# Altium schematic sheet POWER.SchDoc
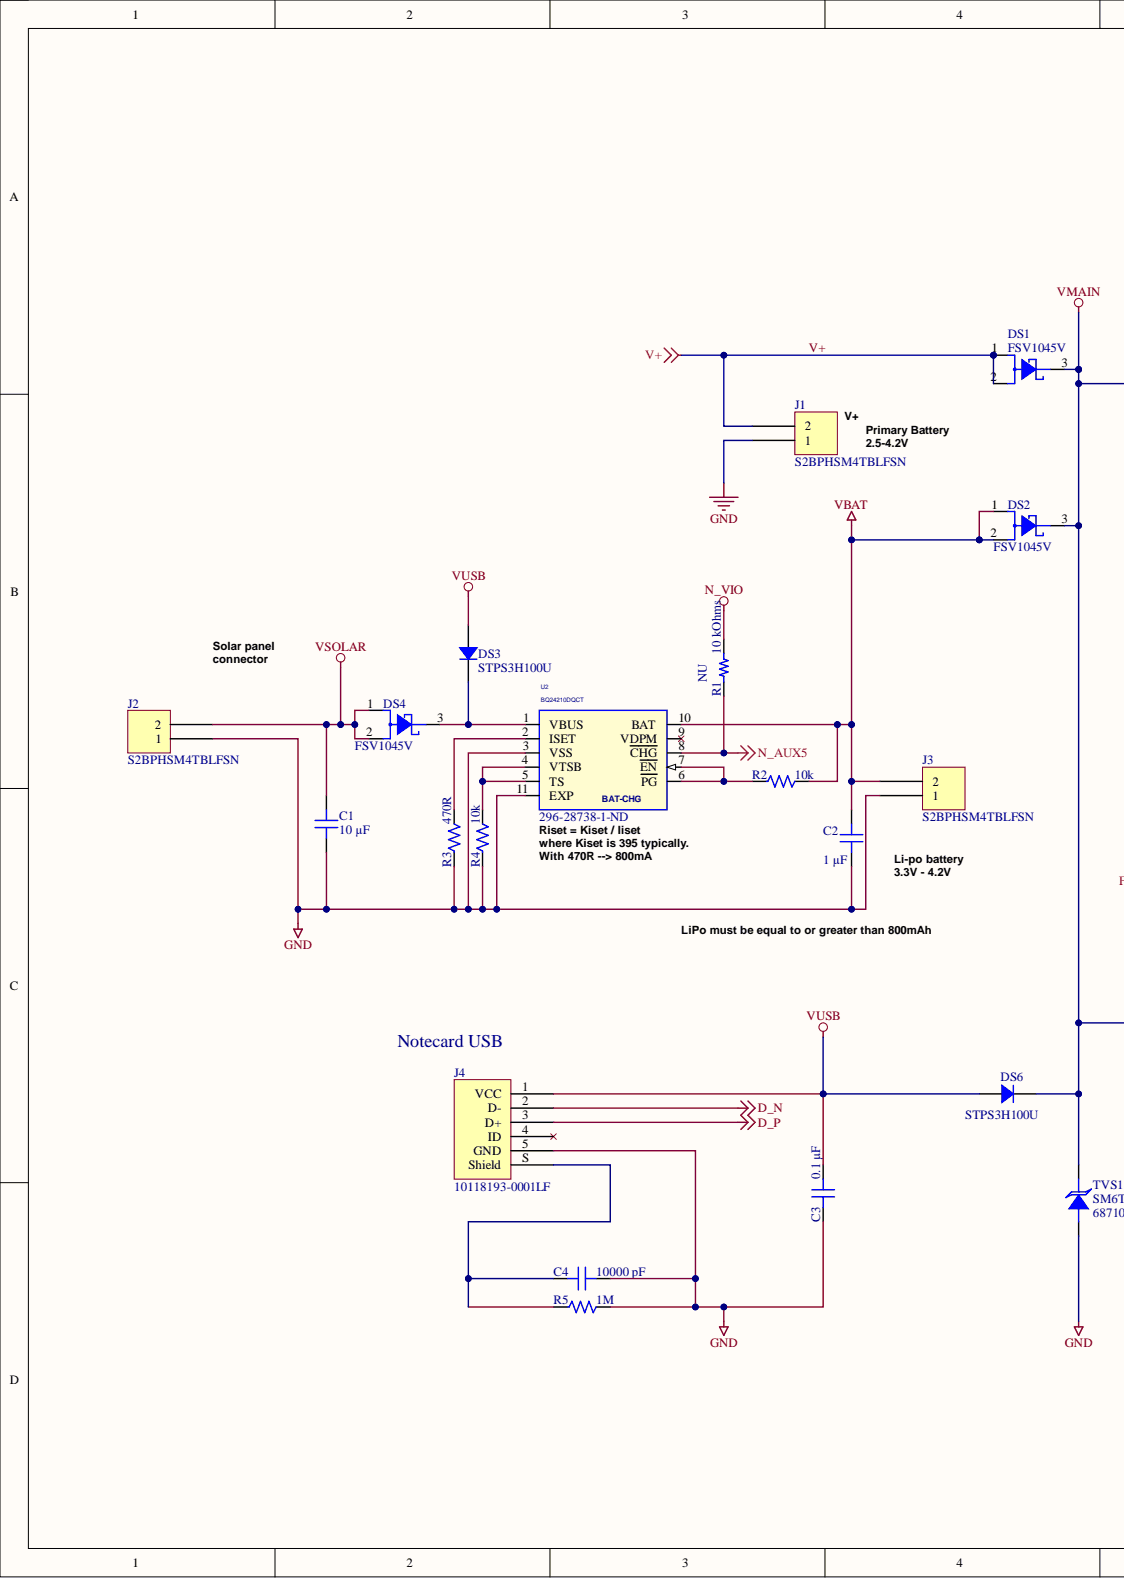
[diagram p0: POWER.SchDoc, left]
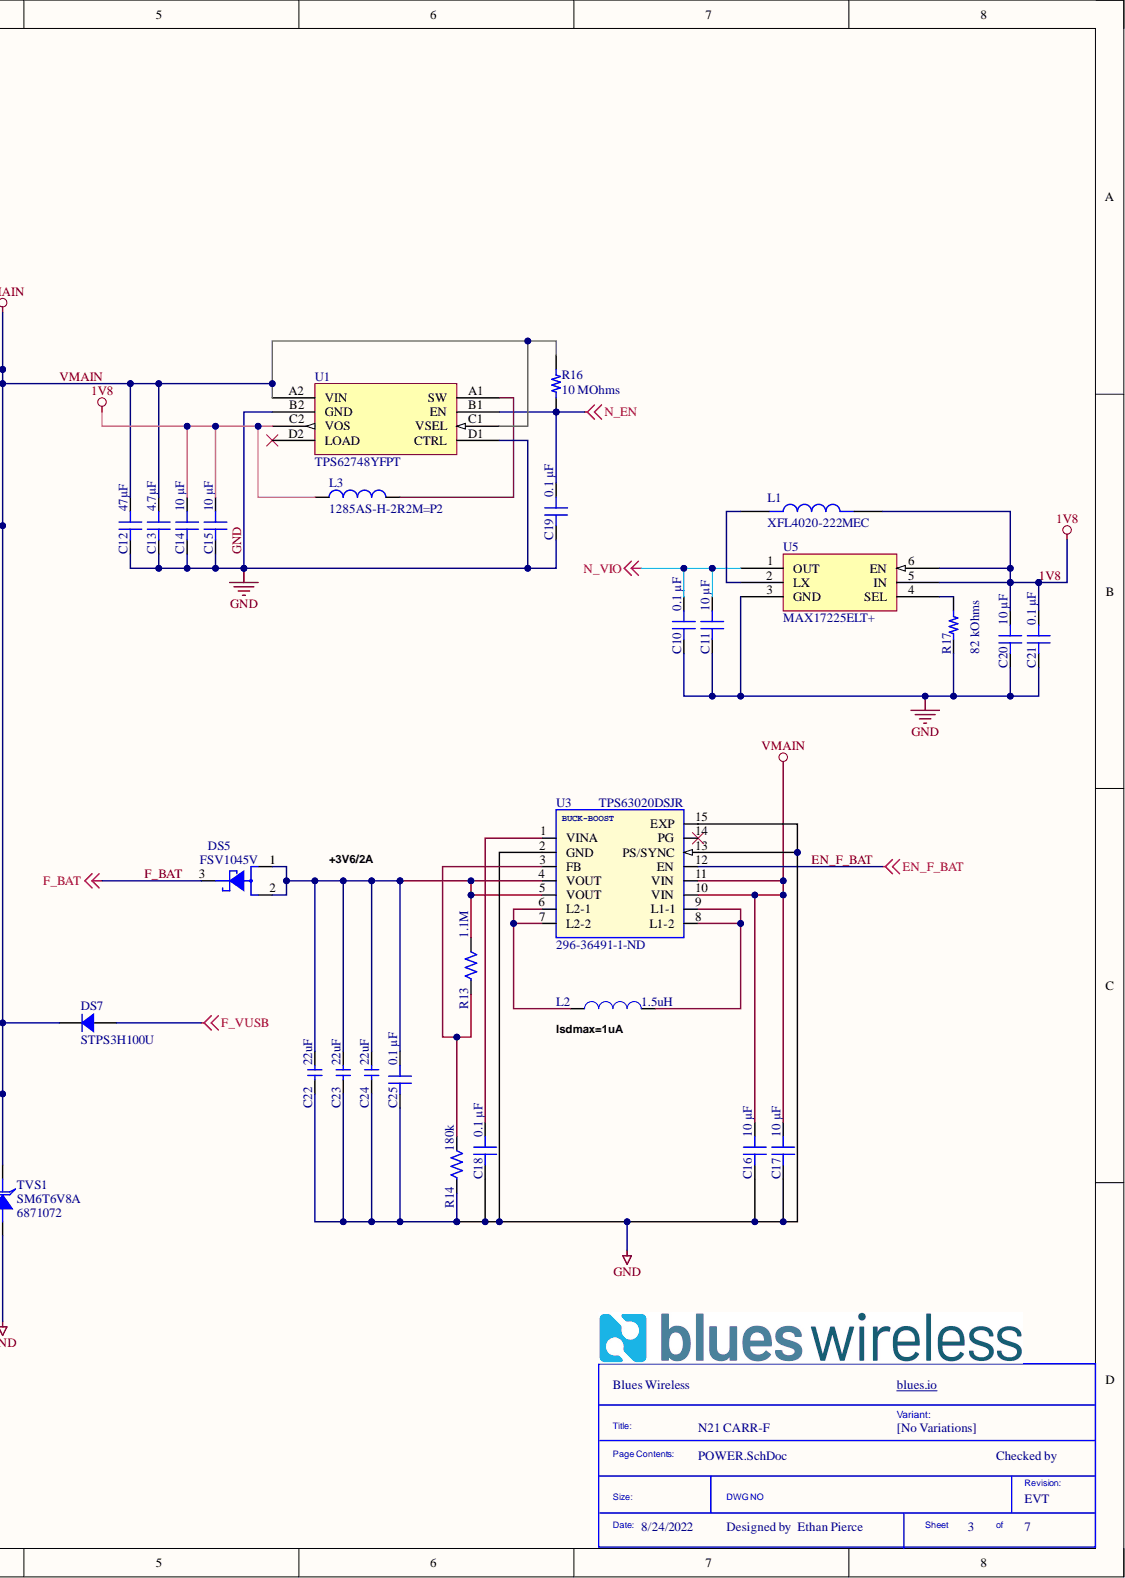
[diagram p1: POWER.SchDoc, right]

## Parts
C1  10 µF  [CAP 1206_3216 - 0.8MM]
C2  1 µF  [CAP 0402_1005]
C3  0.1 µF  [CAP 0402_1005]
C4  10000 pF  [CAP 1206_3216 - 0.8MM]
C10  0.1 µF  [CAP 0402_1005]
C11  10 µF  [CAP 0402_1005]
C12  47µF  [CAP 1210_3225 - 2.8MM]
C13  4.7µF  [CAP 0603_1608]
C14  10 µF  [CAP 0402_1005]
C15  10 µF  [CAP 0402_1005]
C16  10 µF  [CAP 0603_1608]
C17  10 µF  [CAP 0603_1608]
C18  0.1 µF  [CAP 0402_1005]
C19  0.1 µF  [CAP 0402_1005]
C20  10 µF  [CAP 0402_1005]
C21  0.1 µF  [CAP 0402_1005]
C22  22uF  [CAP FILM 0805]
C23  22uF  [CAP FILM 0805]
C24  22uF  [CAP FILM 0805]
C25  0.1 µF  [CAP 0402_1005]
DS1  FSV1045V  [TO277-3]
DS2  FSV1045V  [TO277-3]
DS3  STPS3H100U  [DO214AA SMB]
DS4  FSV1045V  [TO277-3]
DS5  FSV1045V  [TO277-3]
DS6  STPS3H100U  [DO214AA SMB]
DS7  STPS3H100U  [DO214AA SMB]
J1  S2BPHSM4TBLFSN  [JST S2B-PH-SM4-TB]
J2  S2BPHSM4TBLFSN  [JST S2B-PH-SM4-TB]
J3  S2BPHSM4TBLFSN  [JST S2B-PH-SM4-TB]
J4  10118193-0001LF  [FCI USB 10118193-0001LF]
L1  XFL4020-222MEC  [IND_XFL4020-222MEC]
L2  1.5uH  [VCHA042A-1R5MS6]
L3  1285AS-H-2R2M=P2  [1285AS-H-2R2M=P2-INDC2016X100N]
R1  10 kOhms  [RES 0402_1005]  (no BOM)
R2  10k  [RES 0402_1005]
R3  470R  [RES 0402_1005]
R4  10k  [RES 0402_1005]
R5  1M  [RES 1206_3216]
R13  1.1M  [RES 0402_1005]
R14  180k  [RES 0402_1005]
R16  10 MOhms  [RES 0402_1005]
R17  82 kOhms  [RES 0402_1005]
TVS1  SM6T6V8A  Supplier Part Number 1: 6871072  [SMB]
U1  TPS62748YFPT  [TPS62748YFPT-DSBGA4x2]
U2  BQ24210DQCT  Supplier Part Number 1: 296-28738-1-ND  [WSON10-EXP]
U3  TPS63020DSJR  ROOM: BUCK-BOOST  Supplier Part Number 1: 296-36491-1-ND  [TI PVSON-14 DSJ]
U5  MAX17225ELT+  [MAX17225ELT+UDFN]

## Nets
D_N: J4.2(D-)
D_P: J4.3(D+)
F_VUSB: DS7.1
N_AUX5: R1.1 U2.8(CHG)
N_EN: C19.1 R16.1 U1.B1(EN)
N_VIO: C10.1 C11.2 R1.2 U5.1(OUT)
VBAT: C2.2 DS2.1 DS2.2 J3.2 R2.2 U2.10(BAT)
VSOLAR: C1.1 DS4.1 DS4.2 J2.2
VUSB: C3.1 DS3.1 DS6.1 J4.1(VCC)
1V8: C14.2 C15.2 C20.2 C21.1 L1.2 L3.1 U1.C2(VOS) U5.5(IN) U5.6(EN)
EN_F_BAT: U3.12(EN)
F_BAT: DS5.3
GND: C1.2 C2.1 C3.2 C4.2 C10.2 C11.1 C12.1 C13.1 C14.1 C15.1 C16.1 C17.1 C18.1 C19.2 C20.1 C21.2 C22.2 C23.2 C24.2 C25.1 J1.1 J2.1 J3.1 J4.5(GND) R3.1 R4.1 R5.2 R14.1 R17.1 TVS1.2 U1.B2(GND) U1.D1(CTRL) U2.3(VSS) U2.11(EXP) U3.2(GND) U3.13(PS/SYNC) U3.15(EXP) U5.3(GND)
V+: DS1.1 DS1.2 J1.2
VMAIN: C12.2 C13.2 C16.2 C17.2 DS1.3 DS2.3 DS6.2 DS7.2 R16.2 TVS1.1 U1.A2(VIN) U1.C1(VSEL) U3.10(VIN) U3.11(VIN)
NetC4_1: C4.1 J4.S(Shield) R5.1
NetC18_2: C18.2 U3.1(VINA)
NetC22_1: C22.1 C23.1 C24.1 C25.2 DS5.1 DS5.2 R13.2 U3.4(VOUT) U3.5(VOUT)
NetDS3_2: DS3.2 DS4.3 U2.1(VBUS)
NetL1_1: L1.1 U5.2(LX)
NetL2_1: L2.1 U3.6(L2-1) U3.7(L2-2)
NetL2_2: L2.2 U3.8(L1-2) U3.9(L1-1)
NetL3_2: L3.2 U1.A1(SW)
NetR2_1: R2.1 U2.6(PG) U2.7(EN)
NetR3_2: R3.2 U2.2(ISET)
NetR4_2: R4.2 U2.4(VTSB) U2.5(TS)
NetR13_1: R13.1 R14.2 U3.3(FB)
NetR17_2: R17.2 U5.4(SEL)

Not connected: J4 4(ID); U1 D2(LOAD); U2 9(VDPM); U3 14(PG)

## Library data of the parts
C1 = CL31A106KAHNNNE: CAP CER 10UF 25V X5R 1206; manufacturer: Samsung Electro-Mechanics; Database Table Name: Capacitors - Ceramic - 1206; Tolerance: ±10%; Applications: General Purpose; Capacitance: 10 µF; Component Kind: Standard; componentlink1description: Datasheet; componentlink1url: http://www.samsungsem.com/kr/support/product-search/mlcc/CL31A106KAHNNNE.jsp; componentlink2description: DigiKey Link; componentlink2url: https://www.digikey.com/en/products/detail/samsung-electro-mechanics/CL31A106KAHNNNE/3886733; Device Package: 1206 (3216 Metric); lastupdated: 3/13/2022 5:23:44 AM; Library Name: Celestial Altium Library - altium_library.DbLib; Lifecycle Status: Active; Minimum Order: 1; Mounting Type: Surface Mount, MLCC; Operating Temperature: -55°C ~ 85°C; Package / Case: 1206 (3216 Metric); packaging: TapeAndReel; Part Number: CL31A106KAHNNNE; Part Status: Active; partid: 21774; price: 0.03; series: CL; Size / Dimension: 0.126" L x 0.063" W (3.20mm x 1.60mm); Supplier 1: DigiKey; Supplier Part Number 1: 1276-1075-1-ND; Temperature Coefficient: X5R; Thickness (Max): 0.071" (1.80mm); Value: 10 µF; Voltage - Rated: 25V
C2 = CL05A105KO5NNNC: CAP CER 1UF 16V X5R 0402; manufacturer: Samsung Electro-Mechanics; Database Table Name: Capacitors - Ceramic - 0402; Tolerance: ±10%; Applications: General Purpose; Capacitance: 1 µF; Component Kind: Standard; componentlink1description: Datasheet; componentlink1url: http://www.samsungsem.com/kr/support/product-search/mlcc/CL05A105KO5NNNC.jsp; componentlink2description: DigiKey Link; componentlink2url: https://www.digikey.com/en/products/detail/samsung-electro-mechanics/CL05A105KO5NNNC/3886725; Device Package: 0402 (1005 Metric); lastupdated: 3/13/2022 5:15:31 AM; Library Name: Celestial Altium Library - altium_library.DbLib; Lifecycle Status: Active; Minimum Order: 1; Mounting Type: Surface Mount, MLCC; Operating Temperature: -55°C ~ 85°C; Package / Case: 0402 (1005 Metric); packaging: TapeAndReel; Part Number: CL05A105KO5NNNC; Part Status: Active; partid: 3120; price: 0.01; series: CL; Size / Dimension: 0.039" L x 0.020" W (1.00mm x 0.50mm); Supplier 1: DigiKey; Supplier Part Number 1: 1276-1067-1-ND; Temperature Coefficient: X5R; Thickness (Max): 0.022" (0.55mm); Value: 1 µF; Voltage - Rated: 16V
C3 C10 C18 C19 C21 C25 = CL05B104KO5NNNC: CAP CER 0.1UF 16V X7R 0402; manufacturer: Samsung Electro-Mechanics; Database Table Name: Capacitors - Ceramic - 0402; Tolerance: ±10%; Applications: General Purpose; Capacitance: 0.1 µF; Component Kind: Standard; componentlink1description: Datasheet; componentlink1url: http://www.samsungsem.com/kr/support/product-search/mlcc/CL05B104KO5NNNC.jsp; componentlink2description: DigiKey Link; componentlink2url: https://www.digikey.com/en/products/detail/samsung-electro-mechanics/CL05B104KO5NNNC/3886659; Device Package: 0402 (1005 Metric); lastupdated: 3/13/2022 5:15:23 AM; Library Name: Celestial Altium Library - altium_library.DbLib; Lifecycle Status: Active; Minimum Order: 1; Mounting Type: Surface Mount, MLCC; Operating Temperature: -55°C ~ 125°C; Package / Case: 0402 (1005 Metric); packaging: CutTape; Part Number: CL05B104KO5NNNC; Part Status: Active; partid: 6066; price: 0.01; series: CL; Size / Dimension: 0.039" L x 0.020" W (1.00mm x 0.50mm); Supplier 1: DigiKey; Supplier Part Number 1: 1276-1001-1-ND; Temperature Coefficient: X7R; Thickness (Max): 0.022" (0.55mm); Value: 0.1 µF; Voltage - Rated: 16V
C4 = CS1206KKX7RCBB103: CAP CER 10000PF 1KV X7R 1206; manufacturer: Yageo; Database Table Name: Capacitors - Ceramic - 1206; Tolerance: ±10%; Voltage - Rated: 1000V (1kV); Applications: Boardflex Sensitive; Capacitance: 10000 pF; Component Kind: Standard; componentlink1description: Datasheet; componentlink1url: http://www.yageo.com/NewPortal/yageodocoutput?fileName=/pdf/MLCC/UPY-ST_NPOX7R_16V-to-3KV_5.pdf; componentlink2description: DigiKey Link; componentlink2url: https://www.digikey.com/en/products/detail/yageo/CS1206KKX7RCBB103/6098026; Device Package: 1206 (3216 Metric); Features: Soft Termination; lastupdated: 3/13/2022 5:23:50 AM; Library Name: Celestial Altium Library - altium_library.DbLib; Lifecycle Status: Active; Minimum Order: 1; Mounting Type: Surface Mount, MLCC; Operating Temperature: -55°C ~ 125°C; Package / Case: 1206 (3216 Metric); packaging: TapeAndReel; Part Number: CS1206KKX7RCBB103; Part Status: Active; partid: 21090; price: 0.08; series: CS; Size / Dimension: 0.126" L x 0.063" W (3.20mm x 1.60mm); Supplier 1: DigiKey; Supplier Part Number 1: 311-3578-1-ND; Temperature Coefficient: X7R; Thickness (Max): 0.057" (1.45mm); Value: 10000 pF
C11 C14 C15 C20 = GRJ155R60J106ME11D: CAP CER 10UF 6.3V X5R 0402; manufacturer: Murata Electronics; Database Table Name: Capacitors - Ceramic - 0402; Tolerance: ±20%; Applications: Boardflex Sensitive; Capacitance: 10 µF; Component Kind: Standard; componentlink1description: Datasheet; componentlink1url: https://psearch.en.murata.com/capacitor/product/GRJ155R60J106ME11%23.pdf; componentlink2description: DigiKey Link; componentlink2url: https://www.digikey.com/en/products/detail/murata-electronics/GRJ155R60J106ME11D/5877374; Device Package: 0402 (1005 Metric); Features: Soft Termination; lastupdated: 3/13/2022 5:15:21 AM; Library Name: Celestial Altium Library - altium_library.DbLib; Lifecycle Status: Active; Minimum Order: 1; Mounting Type: Surface Mount, MLCC; Operating Temperature: -55°C ~ 85°C; Package / Case: 0402 (1005 Metric); packaging: TapeAndReel; Part Number: GRJ155R60J106ME11D; Part Status: Active; partid: 6740; price: 0.02; series: GRJ; Size / Dimension: 0.039" L x 0.020" W (1.00mm x 0.50mm); Supplier 1: DigiKey; Supplier Part Number 1: 490-13211-1-ND; Temperature Coefficient: X5R; Thickness (Max): 0.028" (0.70mm); Value: 10 µF; Voltage - Rated: 6.3V
C12 = 1210YD476KAT2A: CAP CER 47UF 16V X5R 1210; manufacturer: AVX Corporation; Database Table Name: Capacitors - Ceramic - 1210; Tolerance: ±10%; Applications: General Purpose; Capacitance: 47µF; Component Kind: Standard; componentlink1description: Datasheet; componentlink1url: http://datasheets.avx.com/cx5r.pdf; componentlink2description: DigiKey Link; componentlink2url: http://digikey.com/product-detail/en/avx-corporation/1210YD476KAT2A/478-11989-1-ND/8573625; Device Package: 1210 (3225 Metric); lastupdated: 9/2/2020 1:10:52 AM; Library Name: Celestial Altium Library - altium_library.DbLib; Lifecycle Status: Active; Minimum Order: 1; Mounting Type: Surface Mount, MLCC; Operating Temperature: -55°C ~ 85°C; Package / Case: 1210 (3225 Metric); packaging: TapeAndReel; Part Number: 1210YD476KAT2A; Part Status: Active; partid: 23691; price: 0.60; series: -; Size / Dimension: 0.132" L x 0.098" W (3.35mm x 2.50mm); Supplier 1: DigiKey; Supplier Part Number 1: 478-11989-1-ND; Temperature Coefficient: X5R; Thickness (Max): 0.110" (2.80mm); Value: 47µF; Voltage - Rated: 16V
C13 = 06036D475KAT4A: CAP CER 4.7UF 6.3V X5R 0603; manufacturer: AVX Corporation; Database Table Name: Capacitors - Ceramic - 0603; Tolerance: ±10%; Applications: General Purpose; Capacitance: 4.7µF; Component Kind: Standard; componentlink1description: Datasheet; componentlink1url: http://datasheets.avx.com/cx5r.pdf; componentlink2description: DigiKey Link; componentlink2url: http://digikey.com/product-detail/en/avx-corporation/06036D475KAT4A/478-10813-1-ND/7536337; Device Package: 0603 (1608 Metric); lastupdated: 9/2/2020 1:03:34 AM; Library Name: Celestial Altium Library - altium_library.DbLib; Lifecycle Status: Active; Minimum Order: 1; Mounting Type: Surface Mount, MLCC; Operating Temperature: -55°C ~ 85°C; Package / Case: 0603 (1608 Metric); packaging: TapeAndReel; Part Number: 06036D475KAT4A; Part Status: Active; partid: 2929; price: 0.02; series: -; Size / Dimension: 0.063" L x 0.032" W (1.60mm x 0.81mm); Supplier 1: DigiKey; Supplier Part Number 1: 478-10813-1-ND; Temperature Coefficient: X5R; Thickness (Max): 0.037" (0.94mm); Value: 4.7µF; Voltage - Rated: 6.3V
C16 C17 = CL10A106KP8NNNC: CAP CER 10UF 10V X5R 0603; manufacturer: Samsung Electro-Mechanics; Database Table Name: Capacitors - Ceramic - 0603; Tolerance: ±10%; Applications: General Purpose; Capacitance: 10 µF; Component Kind: Standard; componentlink1description: Datasheet; componentlink1url: http://www.samsungsem.com/kr/support/product-search/mlcc/CL10A106KP8NNNC.jsp; componentlink2description: DigiKey Link; componentlink2url: https://www.digikey.com/en/products/detail/samsung-electro-mechanics/CL10A106KP8NNNC/3886850; Device Package: 0603 (1608 Metric); lastupdated: 3/13/2022 5:18:34 AM; Library Name: Celestial Altium Library - altium_library.DbLib; Lifecycle Status: Active; Minimum Order: 1; Mounting Type: Surface Mount, MLCC; Operating Temperature: -55°C ~ 85°C; Package / Case: 0603 (1608 Metric); packaging: TapeAndReel; Part Number: CL10A106KP8NNNC; Part Status: Active; partid: 12919; price: 0.02; series: CL; Size / Dimension: 0.063" L x 0.031" W (1.60mm x 0.80mm); Supplier 1: DigiKey; Supplier Part Number 1: 1276-1192-1-ND; Temperature Coefficient: X5R; Thickness (Max): 0.035" (0.90mm); Value: 10 µF; Voltage - Rated: 10V
C22-C24 = CL21A226MOQNNNE: 贴片电容（MLCC） 22µF ±20% 16V X5R 0805; Manufacturer: Samsung; Capacitance: 22uF; Case Code (Imperial): 0805; Case Code (Metric): 2012; Case/Package: 0805; Category: Ceramic Capacitors; Component Kind: Standard; ComponentLink1Description: https://datasheet.ciiva.com/25724/cl21a226moqnnne-25724832.pdf?src-supplier=Digi-Key; ComponentLink1URL: https://datasheet.ciiva.com/25724/cl21a226moqnnne-25724832.pdf?src-supplier=Digi-Key; Construction: Flat; Contact Plating: Tin; Dielectric: X5R; Lead Free: Lead Free; Length: 0.079inch; Library Name: n21-p2.SchLib; Manufacturer Part Number: CL21A226MOQNNNE; Max Operating Temperature: 85°C; Min Operating Temperature: -55°C; Mount: Surface Mount; Package Quantity: 1; Packaging: Cut Tape; Pricing 1: 2000=0.03780, 4000=0.03726, 8000=0.03618, 12000=0.03537, 16000=0.03402 (USD); RoHS: Yes; RoHS Compliant: Yes; Series: CL; Stock 1: 752000; Supplier 1: Avnet; Supplier Part Number 1: CL21A226MOQNNNE; Termination: Wraparound; Thickness: 0.055inch; Tolerance: 20%; Voltage Rating: 16V; Voltage Rating (DC): 16V; Width: 0.049inch
DS1 DS2 DS4 DS5 = SCHOTTKY - FSV1045V - 10A/45V: DIODO SCHOTTKY 10A 45V FSV1045V TO277-3 SMT; Database: 42269; Pkg Type: SMD; ROOM: SOLAR BATTERY CHARGER; Temp Range: -55°..+150°; Caratteristiche: 10A/45V; Component Kind: Standard; Distributor: FAE; Famiglia: DIODO; Library Name: n21-p2.SchLib; Modello: SCHOTTKY; MPN: FSV1045V; PCB Footprint: TO277-3; Price Distributor Cad: 0,629; Supplier 1: Digi-Key; Supplier Part Number 1: FSV1045VCT-ND
DS3 DS6 DS7 = STPS3H100U: DIODE SCHOTTKY 100V 3A SMB; Manufacturer: STMicroelectronics; Current - Reverse Leakage @ Vr: 1 µA @ 100 V; Database Table Name: Diodes - Rectifiers - Single; Speed: Fast Recovery =< 500ns, > 200mA (Io); Voltage - Forward (Vf) (Max) @ If: 840 mV @ 3 A; Component Kind: Standard; ComponentLink1Description: Datasheet; ComponentLink1URL: //media.digikey.com/pdf/Data%20Sheets/ST%20Microelectronics%20PDFS/STPS3H100.pdf; ComponentLink2Description: DigiKey Link; ComponentLink2URL: https://www.digikey.com/en/products/detail/stmicroelectronics/STPS3H100U/2236916; Current - Average Rectified (Io): 3A; Diode Type: Schottky; LastUpdated: 5/12/2022 2:47:56 PM; Library Name: Celestial Altium Library - altium_library.DbLib; Lifecycle Status: Active; Minimum Order: 1; Mounting Type: Surface Mount; Operating Temperature - Junction: 175°C (Max); Package / Case: DO-214AA, SMB; Packaging: TapeAndReel; Part Number: STPS3H100U; Part Status: Active; PartId: 1551; Price: 0.29; Series: -; Supplier 1: DigiKey; Supplier Device Package: SMB; Supplier Part Number 1: 497-10392-1-ND; Voltage - DC Reverse (Vr) (Max): 100 V
J1-J3 = S2BPHSM4TBLFSN: PH SMT SIDE ENTRY HDR 2W; Manufacturer: JST; Connector Type: Header; Contact Resistance: 20mR; Current Rating: 2A; Gender: Male; Insulation Resistance: 1GR; Max Voltage Rating (AC): 100V; Number of Contacts: 2; Pitch: 2mm; Category: Connectors; Color: Natural,White; Component Kind: Standard; ComponentLink1Description: https://datasheet.ciiva.com/712/eph-712514.pdf?src-supplier=Digi-Key; ComponentLink1URL: https://datasheet.ciiva.com/712/eph-712514.pdf?src-supplier=Digi-Key; Contact Finish - Mating: TIN; Contact Material: Copper Alloy,Bronze,Tin,Brass; Contact Mating Length: 0.13inch; Contact Plating: Tin,Copper; Contact Shape: Square; Contact Type: Male Pin; Depth: 7.6mm; Fastening Type: Detent; Flammability Rating: UL94 V-0; Height: 9.4mm; Housing Color: Beige; Housing Material: Polyamide; Insulation Color: Natural; Insulation Material: Nylon; Lead Free: Lead Free; Lead Pitch: 2mm; Length: 7.9mm; Library Name: n21-p2.SchLib; Manufacturer Part Number: S2BPHSM4TBLFSN; Max Current Rating: 2A; Max Operating Temperature: 85°C; Max Voltage Rating (DC): 100V; Min Operating Temperature: -25°C; Mount: Surface Mount; Number of Poles: 2; Number of Positions: 2; Number of Rows: 1; Orientation: Right Angle; Packaging: Tape and Reel; REACH SVHC: No SVHC; RoHS: Yes; Series: PH; Supplier 1: Digi-Key; Supplier Part Number 1: 455-1749-1-ND; Termination: Solder; Voltage Rating: 100V; Voltage Rating (AC): 100V; Width: 5mm; Working Voltage: 100V
J4 = 10118193-0001LF: CONN RCPT USB2.0 MICRO B SMD R/A; Manufacturer: Amphenol ICC (FCI); Connector Type: USB - micro B; Current Rating (Amps): 1.8A per Contact; Database Table Name: Connectors - USB DVI HDMI; Gender: Receptacle; Mating Cycles: 10000; Number of Contacts: 5; Number of Ports: 1; Shielding: Shielded; Voltage - Rated: 100VAC; Component Kind: Standard; ComponentLink1Description: Datasheet; ComponentLink1URL: https://cdn.amphenol-icc.com/media/wysiwyg/files/documentation/datasheet/inputoutput/io_usb_micro.pdf; ComponentLink2Description: DigiKey Link; ComponentLink2URL: //digikey.com/product-detail/en/amphenol-icc-fci/10118193-0001LF/609-4616-2-ND/2785388; Features: Solder Retention; LastUpdated: 12/9/2019 8:51:28 PM; Library Name: Celestial Altium Library - altium_library.DbLib; Lifecycle Status: 0; Minimum Order: 1; Mounting Feature: Horizontal; Mounting Type: Surface Mount, Right Angle; Through Hole; Operating Temperature: -30°C ~ 80°C; Packaging: TapeAndReel; Part Number: 10118193-0001LF; Part Status: Active; Price: 0.21; Series: -; Specifications: USB 2.0; Supplier 1: DigiKey; Supplier Part Number 1: 609-4616-2-ND; Termination: Solder
L1 = XFL4020-222MEC: Power inductor, shielded, 20% tol, SMT, RoHS, halogen free; MF: {'manufacturer': u'Coilcraft'}; Availability: Unavailable; Component Kind: Standard; Library Name: n21-p2.SchLib; MP: XFL4020-222MEC; Package: None; Price: None
L2 = VCHA042A-1R5MS6: Fixed Ind 1.5UH 7.3A 15.4 Mohm; Manufacturer: Cyntec; Category: Fixed Inductors; Component Kind: Standard; Library Name: n21-p2.SchLib; Manufacturer Part Number: VCHA042A-1R5MS6; Pricing 1: 1=1.40000, 10=1.29600, 25=1.19640, 50=0.99680, 100=0.93710, 250=0.83736, 500=0.71776, 1000=0.63800 (USD); RoHS: Yes; Stock 1: 1541; Supplier 1: Digi-Key; Supplier Part Number 1: 2037-VCHA042A-1R5MS6CT-ND
L3 = 1285AS-H-2R2M=P2: Inductor Power Shielded Wirewound 2.2uH 20% 1MHz Metal 1.2A 0.204Ohm DCR 0806 T/R; Manufacturer: Murata; Core Material: Ferrite; Current Rating: 1.5A; DC Resistance (DCR): 204mR; Case Code (Imperial): 0805; Case Code (Metric): 2012; Case/Package: 0805; Category: Fixed Inductors; Component Kind: Standard; ComponentLink1Description: http://www.toko.co.jp/products/pdf/inductors/dfe201610c.pdf?src-supplier=Avnet; ComponentLink1URL: http://www.toko.co.jp/products/pdf/inductors/dfe201610c.pdf?src-supplier=Avnet; ComponentLink2Description: https://www.murata.com/~/media/webrenewal/products/inductor/chip/tokoproducts/wirewoundmetalalloychiptype/m_dfe201610c.ashx?src-supplier=Digi-Key; ComponentLink2URL: https://www.murata.com/~/media/webrenewal/products/inductor/chip/tokoproducts/wirewoundmetalalloychiptype/m_dfe201610c.ashx?src-supplier=Digi-Key; Depth: 1.6mm; Height - Seated (Max): 0.039inch; Inductance: 2.2uH; Length: 0.079inch; Library Name: n21-p2.SchLib; Manufacturer Part Number: 1285AS-H-2R2M=P2; Max DC Current: 1.2A; Max Operating Temperature: 85°C; Min Operating Temperature: -40°C; Mount: Surface Mount; Packaging: Tape and Reel; Pricing 1: 1=0.40000, 10=0.35300, 25=0.30920, 50=0.26500, 100=0.24280, 250=0.22076, 500=0.20752, 1000=0.15895 (USD); Resistor Type: Wirewound,Metal Alloy; RoHS: Yes; RoHS Compliant: Yes; Shielding: Shielded; Stock 1: 2212; Supplier 1: Digi-Key; Supplier Part Number 1: 490-10600-1-ND; Test Frequency: 1MHz; Tolerance: 20%; Width: 0.063inch
R1 = CRGP0402F10K: RES 10K OHM 1% 1/8W 0402; Manufacturer: TE Connectivity Passive Product; Database Table Name: Resistors - Surface Mount - 0402; Power (Watts): 0.125W, 1/8W; Temperature Coefficient: ±100ppm/°C; ComponentLink1Description: Datasheet; ComponentLink1URL: https://www.te.com/commerce/DocumentDelivery/DDEController?Action=srchrtrv&DocNm=9-1773463-9&DocType=DS&DocLang=English; ComponentLink2Description: DigiKey Link; ComponentLink2URL: https://www.digikey.com/en/products/detail/te-connectivity-passive-product/CRGP0402F10K/8576969; Composition: Thick Film; Device Package: 0402 (1005 Metric); Features: Pulse Withstanding; Height - Seated (Max): 0.016" (0.40mm); LastUpdated: 3/13/2022 5:31:04 AM; Library Name: Celestial Altium Library - altium_library.DbLib; Lifecycle Status: Active; Minimum Order: 1; Number of Terminations: 2; Operating Temperature: -55°C ~ 155°C; Package / Case: 0402 (1005 Metric); Packaging: TapeAndReel; Part Number: CRGP0402F10K; Part Status: Active; PartId: 6238; Price: 0.01; Resistance: 10 kOhms; Series: CRGP; Size / Dimension: 0.043" L x 0.020" W (1.10mm x 0.50mm); Supplier 1: DigiKey; Supplier Device Package: 0402; Supplier Part Number 1: A130367CT-ND; Tolerance: ±1%; Value: 10 kOhms
R2 R4 = RC1005F103CS: Res Thick Film 0402 10K Ohm 1% 1/16W ±100ppm/°C Molded SMD SMD T/R; Manufacturer: Samsung; Max Power Dissipation: 63mW; Resistance: 10kR; Case Code (Imperial): 0402; Case Code (Metric): 1005; Case/Package: 0402; Category: Chip SMD Resistors; Component Kind: Standard; ComponentLink1Description: https://datasheet.ciiva.com/15196/getdatasheetpartid-504789-15196214.pdf?src-supplier=Verical; ComponentLink1URL: https://datasheet.ciiva.com/15196/getdatasheetpartid-504789-15196214.pdf?src-supplier=Verical; ComponentLink2Description: https://datasheet.ciiva.com/16481/rcseries-16481007.pdf?src-supplier=Future+Electronics; ComponentLink2URL: https://datasheet.ciiva.com/16481/rcseries-16481007.pdf?src-supplier=Future+Electronics; Construction: Rectangular; Contact Plating: Tin; Depth: 0.5mm; Features: Moisture Resistant; Height: 0.016inch; Length: 0.039inch; Library Name: n21-p2.SchLib; Manufacturer Part Number: RC1005F103CS; Max Operating Temperature: 155°C; Military Standard: Not; Min Operating Temperature: -55°C; Mount: Surface Mount; Number of Terminations: 2; Package Quantity: 1; Packaging: Tape and Reel; Power Rating: 63mW; Pricing 1: 10000=0.00695 (USD); Radiation Hardening: No; Resistor Type: Thick Film; RoHS: Yes; RoHS Compliant: Yes; Series: RC; Size Code: 0402; Stock 1: 58620569; Supplier 1: Digi-Key; Supplier Part Number 1: 1276-3431-2-ND; Temperature Coefficient: 100ppm/°C; Tolerance: 1%; Width: 0.02inch
R3 = RC0402FR-07470RL: Res Thick Film 0402 470 Ohm 1% 0.063W(1/16W) ±100ppm/C Pad SMD T/R; Manufacturer: Yageo; Max Power Dissipation: 63mW; Power Rating: 62.5mW; Temperature Coefficient: 100ppm/°C; Voltage Rating: 50V; Case Code (Imperial): 0402; Case Code (Metric): 1005; Case/Package: 0402; Category: Chip SMD Resistors; Component Kind: Standard; ComponentLink1Description: https://datasheet.ciiva.com/2453/pyu-r-int-thick-7-2453376.pdf?src-supplier=Digi-Key; ComponentLink1URL: https://datasheet.ciiva.com/2453/pyu-r-int-thick-7-2453376.pdf?src-supplier=Digi-Key; ComponentLink2Description: https://datasheet.ciiva.com/2453/pyu-r-int-thick-7-2453377.pdf?src-supplier=Digi-Key; ComponentLink2URL: https://datasheet.ciiva.com/2453/pyu-r-int-thick-7-2453377.pdf?src-supplier=Digi-Key; ComponentLink3Description: https://datasheet.ciiva.com/2453/pyu-r-int-thick-7-2453378.pdf?src-supplier=Digi-Key; ComponentLink3URL: https://datasheet.ciiva.com/2453/pyu-r-int-thick-7-2453378.pdf?src-supplier=Digi-Key; ComponentLink4Description: https://datasheet.ciiva.com/15189/getdatasheetpartid-330246-15189463.pdf?src-supplier=Verical; ComponentLink4URL: https://datasheet.ciiva.com/15189/getdatasheetpartid-330246-15189463.pdf?src-supplier=Verical; ComponentLink5Description: https://datasheet.ciiva.com/46412/1018594-46412838.pdf?src-supplier=Element14; ComponentLink5URL: https://datasheet.ciiva.com/46412/1018594-46412838.pdf?src-supplier=Element14; ComponentLink6Description: https://datasheet.ciiva.com/7850/1018594-7850014.pdf?src-supplier=Element14; ComponentLink6URL: https://datasheet.ciiva.com/7850/1018594-7850014.pdf?src-supplier=Element14; ComponentLink7Description: https://datasheet.ciiva.com/7850/1018594-7850015.pdf?src-supplier=Element14; ComponentLink7URL: https://datasheet.ciiva.com/7850/1018594-7850015.pdf?src-supplier=Element14; Construction: Rectangular; Depth: 0.5mm; Features: Moisture Resistant; Height: 0.016inch; Lead Free: Lead Free; Length: 1mm; Library Name: n21-p2.SchLib; Manufacturer Part Number: RC0402FR-07470RL; Max Operating Temperature: 155°C; Military Standard: Not; Min Operating Temperature: -55°C; Number of Terminations: 2; Package Quantity: 1; Packaging: Tape and Reel; Pricing 1: 10000=0.00186 (USD); REACH SVHC: Unknown; Resistance: 470R; Resistance Tolerance: 1%; Resistor Type: Thick Film; RoHS: Yes; RoHS Compliant: Yes; Series: RC; Size Code: 0402; Stock 1: 4221525; Supplier 1: Digi-Key; Supplier Part Number 1: 311-470LRTR-ND; Termination: SMD/SMT; Tolerance: 1%; Width: 0.02inch
R5 = RC1206FR-071ML: Res Thick Film 1206 1M Ohm 1% 0.25W(1/4W) ±100ppm/C Pad SMD T/R; Manufacturer: Yageo; Max Power Dissipation: 250mW; Resistance: 1MR; Temperature Coefficient: 100ppm/°C; Voltage Rating: 200V; Case Code (Imperial): 1206; Case Code (Metric): 3216; Case/Package: 1206; Category: Chip SMD Resistors; Component Kind: Standard; ComponentLink1Description: https://datasheet.ciiva.com/11819/0900766b80c6630a-11819912.pdf?src-supplier=RSComponents; ComponentLink1URL: https://datasheet.ciiva.com/11819/0900766b80c6630a-11819912.pdf?src-supplier=RSComponents; ComponentLink2Description: https://datasheet.ciiva.com/17033/pyu-rc1206-51-rohs-l-4-17033611.pdf?src-supplier=Future+Electronics; ComponentLink2URL: https://datasheet.ciiva.com/17033/pyu-rc1206-51-rohs-l-4-17033611.pdf?src-supplier=Future+Electronics; ComponentLink3Description: https://datasheet.ciiva.com/7857/444357-7857288.pdf?src-supplier=Element14; ComponentLink3URL: https://datasheet.ciiva.com/7857/444357-7857288.pdf?src-supplier=Element14; ComponentLink4Description: https://datasheet.ciiva.com/7857/444357-7857289.pdf?src-supplier=Element14; ComponentLink4URL: https://datasheet.ciiva.com/7857/444357-7857289.pdf?src-supplier=Element14; ComponentLink5Description: https://datasheet.ciiva.com/46445/444357-46445709.pdf?src-supplier=Element14; ComponentLink5URL: https://datasheet.ciiva.com/46445/444357-46445709.pdf?src-supplier=Element14; Construction: Rectangular; Depth: 1.6mm; Features: Moisture Resistant; Height: 0.55mm; Lead Free: Lead Free; Length: 3.1mm; Library Name: n21-p2.SchLib; Manufacturer Part Number: RC1206FR-071ML; Max Operating Temperature: 155°C; Military Standard: Not; Min Operating Temperature: -55°C; Number of Terminations: 2; Package Quantity: 5000; Packaging: Tape and Reel; Power Rating: 250mW; Pricing 1: 5000=0.00970 (USD); REACH SVHC: Unknown; Resistance Tolerance: 1%; Resistor Type: Thick Film; RoHS: Yes; RoHS Compliant: Yes; Series: RC; Size Code: 1206; Stock 1: 1941274; Supplier 1: Digi-Key; Supplier Part Number 1: 311-1.00MFRTR-ND; Technology: THICK FILM; Termination: SMD/SMT; Tolerance: 1%; Width: 1.6mm
R13 = AC0402FR-071M1L: Res Thick Film 0402 1.1M Ohm 1% 0.063W(1/16W) ±100ppm/C Pad SMD Automotive T/R; Manufacturer: Yageo; Max Power Dissipation: 63mW; Power Rating: 62.5mW; Resistance: 1.1MR; Temperature Coefficient: 100ppm/°C; Voltage Rating: 50V; Case Code (Imperial): 0402; Case Code (Metric): 1005; Case/Package: 0402; Category: Chip SMD Resistors; Component Kind: Standard; ComponentLink1Description: http://www.mouser.com/ds/2/447/yageodocoutput-1111992.pdf?src-supplier=Mouser; ComponentLink1URL: http://www.mouser.com/ds/2/447/yageodocoutput-1111992.pdf?src-supplier=Mouser; ComponentLink2Description: http://www.yageo.com/NewPortal/yageodocoutput?fileName=/pdf/R-Chip/PYu-AC_51_RoHS_L_6.pdf&src-supplier=Digi-Key; ComponentLink2URL: http://www.yageo.com/NewPortal/yageodocoutput?fileName=/pdf/R-Chip/PYu-AC_51_RoHS_L_6.pdf&src-supplier=Digi-Key; Features: Moisture Resistant,Automotive AEC-Q200; Height: 0.015inch; Length: 0.039inch; Library Name: n21-p2.SchLib; Manufacturer Part Number: AC0402FR-071M1L; Max Operating Temperature: 155°C; Min Operating Temperature: -55°C; Number of Terminations: 2; Packaging: Tape and Reel; Pricing 1: 1=0.10000, 10=0.02200, 100=0.00900, 1000=0.00402, 2500=0.00349, 5000=0.00288 (USD); Resistor Type: Thick Film; RoHS: Yes; RoHS Compliant: Yes; Series: AC; Stock 1: 15284; Supplier 1: Digi-Key; Supplier Part Number 1: YAG5647CT-ND; Termination: SMD/SMT; Tolerance: 1%; Width: 0.5mm
R14 = RC0402FR-07180KL: Res Thick Film 0402 180K Ohm 1% 1/16W +/-100ppm/ßC Molded SMD Paper T/R; Manufacturer: Yageo; Max Power Dissipation: 63mW; Resistance: 180kR; Temperature Coefficient: 100ppm/°C; Voltage Rating: 50V; Case Code (Imperial): 0402; Case Code (Metric): 1005; Case/Package: 0402; Category: Chip SMD Resistors; Component Kind: Standard; ComponentLink1Description: https://datasheet.ciiva.com/12656/pyu-rc0402-51-rohs-l-6-r-12656911.pdf?src-supplier=Mouser; ComponentLink1URL: https://datasheet.ciiva.com/12656/pyu-rc0402-51-rohs-l-6-r-12656911.pdf?src-supplier=Mouser; ComponentLink2Description: https://datasheet.ciiva.com/17025/pyu-rc0402-51-rohs-l-6-r-17025693.pdf?src-supplier=Future+Electronics; ComponentLink2URL: https://datasheet.ciiva.com/17025/pyu-rc0402-51-rohs-l-6-r-17025693.pdf?src-supplier=Future+Electronics; ComponentLink3Description: https://datasheet.ciiva.com/7849/1018594-7849851.pdf?src-supplier=Element14; ComponentLink3URL: https://datasheet.ciiva.com/7849/1018594-7849851.pdf?src-supplier=Element14; ComponentLink4Description: https://datasheet.ciiva.com/7849/1018594-7849852.pdf?src-supplier=Element14; ComponentLink4URL: https://datasheet.ciiva.com/7849/1018594-7849852.pdf?src-supplier=Element14; ComponentLink5Description: https://datasheet.ciiva.com/7983/1018594-7983497.pdf?src-supplier=Element14; ComponentLink5URL: https://datasheet.ciiva.com/7983/1018594-7983497.pdf?src-supplier=Element14; Construction: Rectangular; Depth: 0.5mm; Features: Moisture Resistant; Lead Free: Lead Free; Length: 1mm; Library Name: n21-p2.SchLib; Manufacturer Part Number: RC0402FR-07180KL; Max Operating Temperature: 155°C; Military Standard: Not; Min Operating Temperature: -55°C; Number of Terminations: 2; Packaging: Tape and Reel; Power Rating: 63mW; Pricing 1: 10000=0.00186 (USD); REACH SVHC: Unknown; Resistance Tolerance: 1%; Resistor Type: Thick Film; RoHS: Yes; RoHS Compliant: Yes; Series: RC; Size Code: 0402; Stock 1: 959378; Supplier 1: Digi-Key; Supplier Part Number 1: 311-180KLRTR-ND; Termination: SMD/SMT; Tolerance: 1%
R16 = RC0402JR-0710ML: RES 10M OHM 5% 1/16W 0402; Manufacturer: Yageo; Database Table Name: Resistors - Surface Mount - 0402; Power (Watts): 0.063W, 1/16W; Temperature Coefficient: ±100ppm/°C; Component Kind: Standard; ComponentLink1Description: Datasheet; ComponentLink1URL: https://www.yageo.com/upload/media/product/productsearch/datasheet/rchip/PYu-RC_Group_51_RoHS_L_10.pdf; ComponentLink2Description: DigiKey Link; ComponentLink2URL: https://www.digikey.com/en/products/detail/yageo/RC0402JR-0710ML/2827649; Composition: Thick Film; Device Package: 0402 (1005 Metric); Features: Moisture Resistant; Height - Seated (Max): 0.016" (0.40mm); LastUpdated: 3/13/2022 5:31:07 AM; Library Name: Celestial Altium Library - altium_library.DbLib; Lifecycle Status: Active; Minimum Order: 1; Number of Terminations: 2; Operating Temperature: -55°C ~ 155°C; Package / Case: 0402 (1005 Metric); Packaging: CutTape; Part Number: RC0402JR-0710ML; Part Status: Active; PartId: 4818; Price: 0.01; Resistance: 10 MOhms; Series: RC_L; Size / Dimension: 0.039" L x 0.020" W (1.00mm x 0.50mm); Supplier 1: DigiKey; Supplier Device Package: 0402; Supplier Part Number 1: 311-10MJRCT-ND; Tolerance: ±5%; Value: 10 MOhms
R17 = RMCF0402FT82K0: RES 82K OHM 1% 1/16W 0402; Manufacturer: Stackpole Electronics Inc; Database Table Name: Resistors - Surface Mount - 0402; Power (Watts): 0.063W, 1/16W; Temperature Coefficient: ±100ppm/°C; Component Kind: Standard; ComponentLink1Description: Datasheet; ComponentLink1URL: https://www.seielect.com/catalog/sei-rmcf_rmcp.pdf; ComponentLink2Description: DigiKey Link; ComponentLink2URL: https://www.digikey.com/en/products/detail/stackpole-electronics-inc/RMCF0402FT82K0/1761664; Composition: Thick Film; Device Package: 0402 (1005 Metric); Features: Automotive AEC-Q200; Height - Seated (Max): 0.016" (0.40mm); LastUpdated: 3/13/2022 5:30:53 AM; Library Name: Celestial Altium Library - altium_library.DbLib; Lifecycle Status: Active; Minimum Order: 1; Number of Terminations: 2; Operating Temperature: -55°C ~ 155°C; Package / Case: 0402 (1005 Metric); Packaging: CutTape; Part Number: RMCF0402FT82K0; Part Status: Active; PartId: 9283; Price: 0.01; Ratings: AEC-Q200; Resistance: 82 kOhms; Series: RMCF; Size / Dimension: 0.039" L x 0.020" W (1.00mm x 0.50mm); Supplier 1: DigiKey; Supplier Device Package: 0402; Supplier Part Number 1: RMCF0402FT82K0CT-ND; Tolerance: ±1%; Value: 82 kOhms
TVS1 = TVS-UNI - SM6T6V8A - 6.8V/600W: DIODO TVS TRANSIL UNIDIR. 600W 6.8V SM6T6V8A SMB SMT; Caratteristiche: 57A/6.8V/600W; Database: 14165; Famiglia: PROTEZIONE; Note: Unidirezionale; Pkg Type: SMD; ROOM: INPUT; Component Kind: Standard; Distributor: FAE; Library Name: n21-p2.SchLib; Modello: TVS; PCB Footprint: SMB; Price Distributor Cad: 0,0348; Supplier 1: RSComponents
U1 = TPS62748YFPT: Conv DC-DC 2.15V to 5.5V Step Down Single-Out 1.2V/1.8V 0.3A 8-Pin DSBGA T/R; Manufacturer: Texas Instruments; Efficiency: 90%; Max Output Current: 300mA; Max Output Voltage: 1.8V; Number of Outputs: 1; Output Configuration: Positive; Output Voltage: 1.2V; Quiescent Current: 360nA; Switching Frequency: 1.2MHz; Synchronous Rectifier: Yes; Category: Power Supply Modules; Component Kind: Standard; ComponentLink1Description: http://www.ti.com/general/docs/lit/getliterature.tsp?genericPartNumber=TPS62748&&fileType=pdf&src-supplier=Element14; ComponentLink1URL: http://www.ti.com/general/docs/lit/getliterature.tsp?genericPartNumber=TPS62748&&fileType=pdf&src-supplier=Element14; ComponentLink2Description: http://www.ti.com/general/docs/lit/getliterature.tsp?genericPartNumber=TPS62748&&fileType=pdf&src-supplier=Farnell; ComponentLink2URL: http://www.ti.com/general/docs/lit/getliterature.tsp?genericPartNumber=TPS62748&&fileType=pdf&src-supplier=Farnell; ComponentLink3Description: http://www.ti.com/lit/ds/symlink/tps62748.pdf?src-supplier=Digi-Key; ComponentLink3URL: http://www.ti.com/lit/ds/symlink/tps62748.pdf?src-supplier=Digi-Key; ComponentLink4Description: http://www.ti.com/general/docs/suppproductinfo.tsp?distId=10&amp;gotoUrl=http%3A%2F%2Fwww.ti.com%2Flit%2Fgpn%2Ftps62748&src-supplier=Digi-Key; ComponentLink4URL: http://www.ti.com/general/docs/suppproductinfo.tsp?distId=10&amp;gotoUrl=http%3A%2F%2Fwww.ti.com%2Flit%2Fgpn%2Ftps62748&src-supplier=Digi-Key; ComponentLink5Description: http://www.ti.com/general/docs/lit/getliterature.tsp?genericPartNumber=TPS62748&&fileType=pdf&src-supplier=Avnet; ComponentLink5URL: http://www.ti.com/general/docs/lit/getliterature.tsp?genericPartNumber=TPS62748&&fileType=pdf&src-supplier=Avnet; Lead Free: Lead Free; Library Name: n21-p2.SchLib; Manufacturer Part Number: TPS62748YFPT; Max Input Voltage: 5.5V; Max Operating Temperature: 125°C; Min Input Voltage: 2.15V; Min Operating Temperature: -40°C; Min Output Voltage: 1.8V; Mount: Surface Mount; Number of Pins: 8; Operating Supply Voltage: 5.5V; Output Current: 300mA; Packaging: Cut Tape; Pricing 1: 1=1.24500, 100=1.02900, 250=0.73900, 1000=0.55600 (USD); REACH SVHC: No SVHC; RoHS: Yes; RoHS Compliant: Yes; Supplier 1: TI store; Supplier Part Number 1: TPS62748YFPT
U2 = BAT-CHARGE - BQ24210DQCT: INTEGRATO BQ24210DQCT WSON10 TEXAS SMT; Caratteristiche: LITIO; Database: 47785; Modello: CARICABATTERIA; Note: Vin=20V - Ichg=800mA; Pkg Type: SMD; ROOM: SOLAR BATTERY CHARGER; Temp Range: -40°..+85°; Component Kind: Standard; Distributor: FAE; Famiglia: INTEGRATO; Library Name: n21-p2.SchLib; MPN: BQ24210DQCT; PCB Footprint: WSON10-EXP; Price Distributor Cad: 1,2778; Supplier 1: Digi-Key
U3 = BUCK-BOOST - TPS63020DSJR: I.C. DC/DC CONVERTER TPS63020DSJR TEXAS VSON14 SMT; Caratteristiche: ADJmA/ADJ; Database: 42016; Famiglia: INTEGRATO; Modello: BUCK; Note: VDD=1V8 - 5V5 - Fsw=2.4MHz - Isw=3.5A; PCB Footprint: IC-TPS6302x; Pkg Type: SMD; Temp Range: -40°..+85°; Component Kind: Standard; Distributor: FAE; Library Name: n21-p2.SchLib; MPN: TPS63020DSJR; Price Distributor Cad: 1,2277; Supplier 1: Digi-Key
U5 = MAX17225ELT+: nanoPower Boost Converter that Extends Battery Life and Reduces Solution Size; Manufacturer: Maxim; Category: Power Supply Modules; Component Kind: Standard; ComponentLink1Description: https://datasheets.maximintegrated.com/en/ds/MAX17220-MAX17225.pdf?src-supplier=Digi-Key; ComponentLink1URL: https://datasheets.maximintegrated.com/en/ds/MAX17220-MAX17225.pdf?src-supplier=Digi-Key; Library Name: n21-p2.SchLib; Manufacturer Part Number: MAX17225ELT+; Mount: Surface Mount; Number of Pins: 6; Packaging: Bulk; RoHS: Yes; Supplier 1: Digi-Key; Supplier Part Number 1: MAX17225ELT+ -ND
